# Altium schematic sheet MCU.SchDoc(MCU)
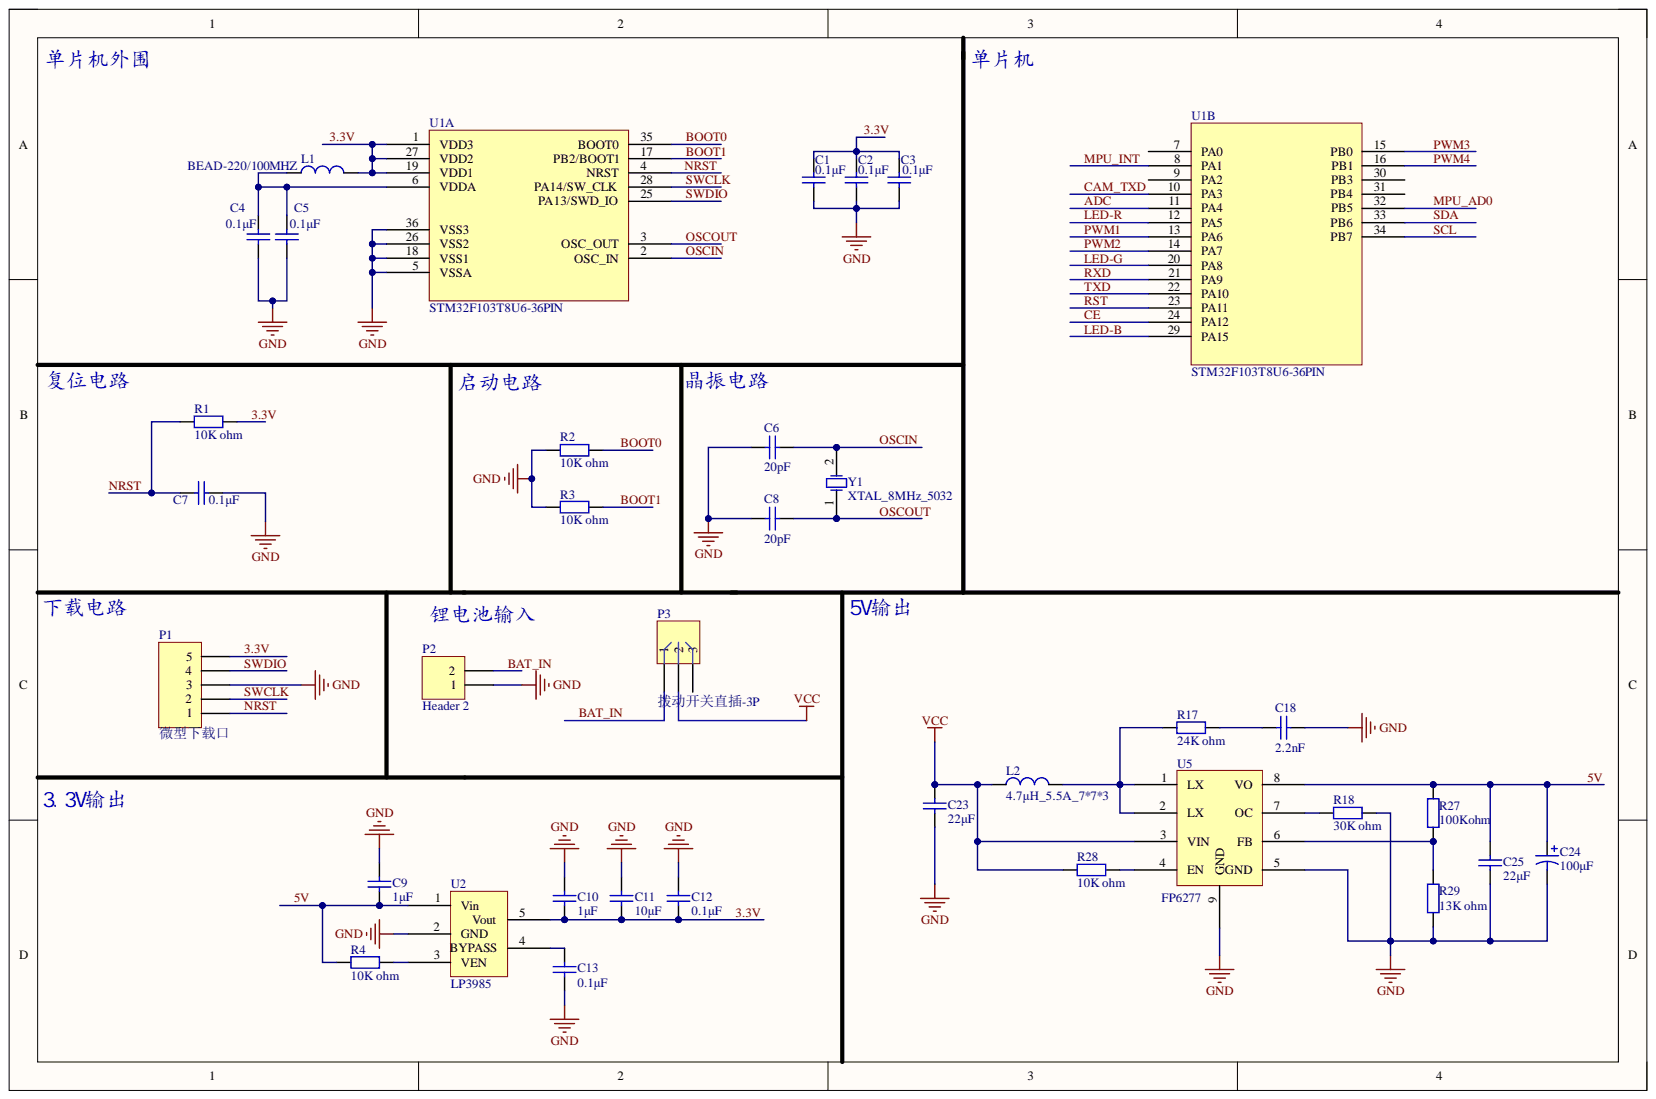
[diagram p0: MCU.SchDoc]

## Parts
C1  0.1μF  [0603C]
C2  0.1μF  [0603C]
C3  0.1μF  [0603C]
C4  0.1μF  [0603C]
C5  0.1μF  [0603C]
C6  20pF  [0603C]
C7  0.1μF  [0603C]
C8  20pF  [0603C]
C9  1μF  [0603C]
C10  1μF  [0603C]
C11  10μF  [0603C]
C12  0.1μF  [0603C]
C13  0.1μF  [0603C]
C18  2.2nF  [0603C]
C23  22μF  [1206C]
C24  100μF  [D7343C]
C25  22μF  [1206C]
L1  BEAD-220/100MHZ  [0603R]
L2  4.7μH_5.5A_7*7*3  [7*7*3mm - 06030]
P1  微型下载口  [微型下载口]
P2  Header 2  [HDR1X2]
P3  拨动开关直插-3P  [拨动开关-3P]
R1  10K ohm  [0603R]
R2  10K ohm  [0603R]
R3  10K ohm  [0603R]
R4  10K ohm  [0603R]
R17  24K ohm  [0603R]
R18  30K ohm  [0603R]
R27  100Kohm  [0603R]
R28  10K ohm  [0603R]
R29  13K ohm  [0603R]
U1  STM32F103T8U6-36PIN  [QFN-36N]  (parts A,B)
U2  LP3985  [SOT-23-5]
U5  FP6277  [SO8 - FP6277]
Y1  XTAL_8MHz_5032  [5032]

## Nets
GND: C1.1 C2.1 C3.1 C4.1 C5.1 C6.1 C7.1 C8.1 C9.1 C10.1 C11.2 C12.2 C13.1 C18.1 C23.1 C24.2 C25.1 P1.3 P2.1 R2.1 R3.1 R18.2 R29.1 U1.5(VSSA) U1.18(VSS1) U1.26(VSS2) U1.36(VSS3) U2.2(GND) U5.5(GND) U5.9(GND)
VCC: C23.2 L2.1 P3.2 R28.1 U5.3(VIN)
3.3V: C1.2 C2.2 C3.2 C10.2 C11.1 C12.1 L1.2 P1.5 R1.2 U1.1(VDD3) U1.19(VDD1) U1.27(VDD2) U2.5(Vout)
5V: C9.2 C24.1 C25.2 R4.1 R27.2 U2.1(Vin) U5.8(VO)
ADC: U1.11(PA4)
BAT_IN: P2.2 P3.1
BOOT0: R2.2 U1.35(BOOT0)
BOOT1: R3.2 U1.17(PB2/BOOT1)
CAM_TXD: U1.10(PA3)
CE: U1.24(PA12)
LED-B: U1.29(PA15)
LED-G: U1.20(PA8)
LED-R: U1.12(PA5)
MPU_AD0: U1.32(PB5)
MPU_INT: U1.8(PA1)
NRST: C7.2 P1.1 R1.1 U1.4(NRST)
OSCIN: C6.2 U1.2(OSC_IN) Y1.2
OSCOUT: C8.2 U1.3(OSC_OUT) Y1.1
PWM1: U1.13(PA6)
PWM2: U1.14(PA7)
PWM3: U1.15(PB0)
PWM4: U1.16(PB1)
RST: U1.23(PA11)
RXD: U1.21(PA9)
SCL: U1.34(PB7)
SDA: U1.33(PB6)
SWCLK: P1.2 U1.28(PA14/SW_CLK)
SWDIO: P1.4 U1.25(PA13/SWD_IO)
TXD: U1.22(PA10)
NetC4_2: C4.2 C5.2 L1.1 U1.6(VDDA)
NetC13_2: C13.2 U2.4(BYPASS)
NetC18_2: C18.2 R17.1
NetL2_2: L2.2 R17.2 U5.1(LX) U5.2(LX)
NetR4_2: R4.2 U2.3(VEN)
NetR18_1: R18.1 U5.7(OC)
NetR27_1: R27.1 R29.2 U5.6(FB)
NetR28_2: R28.2 U5.4(EN)

Not connected: P3 3; U1 7(PA0) 9(PA2) 30(PB3) 31(PB4)

## Library data of the parts
C1-C5 C7 C12 C13 = Cap_0.1μF_50V_0603: 0.1μF_50V,X7R,±5%; 制造商: muRata; 单位: PCS; 物料名称: 贴片陶瓷电容; 物料型号: GRM188R71H104JA93D; 物料编码: TCC0087; Component Kind: Standard; 物料规格: 0.1μF_50V,X7R,±5%; Library Name: Yang_Lib.IntLib
C6 C8 = Cap_20pF_50V_0603: 20pF_50V,CH,±5%; 制造商: muRata; 单位: PCS; 物料名称: 贴片陶瓷电容; 物料型号: GRM1882C1H200JA01D; 物料编码: TCC0036; Component Kind: Standard; 物料规格: 20pF_50V,CH,±5%; Library Name: Yang_Lib.IntLib
C9 C10 = Cap_1μF_50V_0603: 1μF_50V,X5R,±10%; 制造商: muRata; 单位: PCS; 物料名称: 贴片陶瓷电容; 物料型号: GRM188R61H105KAALD; 物料编码: TCC0040; Component Kind: Standard; 物料规格: 1μF_50V,X5R,±10%; Library Name: Yang_Lib.IntLib
C11 = Cap_10μF_35V_0603: 10μF_35V,X5R,20%; 制造商: muRata; 单位: PCS; 物料名称: 贴片陶瓷电容; 物料型号: GRM188R6YA106MA73D; 物料编码: TCC0071; 物料规格: 10μF_35V,20%; Component Kind: Standard; Library Name: Yang_Lib.IntLib
C18 = Cap_2.2nF_50V_0603: 2.2nF_50V,X7R,10%; 制造商: muRata; 单位: PCS; 物料名称: 贴片陶瓷电容; 物料型号: NA; Component Kind: Standard; 物料编码: NA; 物料规格: 2.2nF_50V,X7R,10%; Library Name: Yang_Lib.IntLib
C23 C25 = Cap_22μF_35V_1206: 22μF_35V,20%; 制造商: TDK; 单位: PCS; 物料名称: 贴片陶瓷电容; 物料型号: C3216JB1V226M160AC; 物料编码: NA; Component Kind: Standard; 物料规格: 22μF_35V,20%; Library Name: Yang_Lib.IntLib
C24 = Cap_100μF_16V_D7343: 100μF_16V; 制造商: AVX; 单位: PCS; 物料名称: 钽电容; 物料型号: NA; Component Kind: Standard; 物料编码: NA; 物料规格: 100μF_16V; Library Name: Yang_Lib.IntLib
L1 = BEAD-220/100MHZ_0603: 制造商: TDK; 单位: PCS; 物料名称: 磁珠; 物料型号: MMZ1608B221CTAH0; 物料编码: TCZ0006; Component Kind: Standard; Description: BEAD-220/100MHZ; 物料规格: BEAD-220/100MHZ; Library Name: Yang_Lib.IntLib
L2 = 4.7μH_5.5A_7*7*3: 4.7μH_5.5A_; 制造商: 台湾奇力新; 单位: PCS; 物料名称: 功率电感; 物料型号: MHCC10040-4R7M-R8_06030; 物料编码: NA; Component Kind: Standard; 物料规格: 4.7μH_5.5A_7*7*3; Library Name: Yang_Lib.IntLib
P1 = 微型下载口: 制造商: NA; 单位: PCS; Component Kind: Standard; Description: 微型下载口; 物料名称: 微型下载口; 物料型号: 微型下载口; 物料编码: NA; 物料规格: 微型下载口; Library Name: Yang_Lib.IntLib
P2 = Header 2: Header, 2-Pin; Component Kind: Standard; LatestRevisionDate: 17-Jul-2002; LatestRevisionNote: Re-released for DXP Platform.; Library Name: Miscellaneous Connectors.IntLib; Signal Integrity: Connector; Publisher: Altium Limited
P3 = 拨动开关直插-3P: 制造商: NA; 单位: PCS; Component Kind: Standard; Description: 拨动开关直插-3P; 物料名称: 拨动开关直插-3P; 物料型号: 拨动开关直插-3P; 物料编码: NA; 物料规格: 拨动开关直插-3P; Library Name: Yang_Lib.IntLib
R1-R4 R28 = Res_10K ohm_0603: 10K ohm,1%; 制造商: YAGEO; 单位: PCS; 物料名称: 贴片电阻; 物料型号: RC0603FR-0710KL; 物料编码: TRS0020; Component Kind: Standard; 物料规格: 10K ohm,1%; Library Name: Yang_Lib.IntLib
R17 = Res_24K ohm_0603: 24K ohm,1%; 制造商: YAGEO; 单位: PCS; 物料名称: 贴片电阻; 物料型号: RC0603FR-0724KL; 物料编码: NA; Component Kind: Standard; 物料规格: 24K ohm,1%; Library Name: Yang_Lib.IntLib
R18 = Res_30K ohm_0603: 30K ohm,1%; 制造商: YAGEO; 单位: PCS; 物料名称: 贴片电阻; 物料型号: RC0603FR-0730KL; 物料编码: TRS0028; Component Kind: Standard; 物料规格: 30K ohm,1%; Library Name: Yang_Lib.IntLib
R27 = Res_100Kohm_0603: 100K ohm_5%; 制造商: YAGEO; 单位: PCS; 物料名称: 贴片电阻; 物料型号: RC0603JR-07100K; 物料编码: TRS0032; Component Kind: Standard; 物料规格: 100K ohm_5%; Library Name: Yang_Lib.IntLib
R29 = Res_13K ohm_0603: 13K ohm,1%; 制造商: YAGEO; 单位: PCS; 物料名称: 贴片电阻; Component Kind: Standard; 物料规格: 13K ohm,1%; Library Name: Yang_Lib.IntLib
U1 = STM32F103T8U6-36PIN: 制造商: ST; 单位: PCS; 物料名称: 单片机; 物料编码: NA; Component Kind: Standard; 物料型号: STM32F103T8U6-36PIN; 物料规格: STM32F103T8U6-36PIN; Library Name: Yang_Lib.IntLib
U2 = LP3985: 制造商: National Semiconductor; 单位: PCS; 物料名称: 3.3V LDO; 物料型号: LP3985IM5-3.3/NOPB; 物料编码: TIC0029; Component Kind: Standard; Description: LP3985; 物料规格: LP3985; Library Name: Yang_Lib.IntLib
U5 = FP6277: 制造商: 台湾远翔; 单位: PCS; 物料名称: 升压芯片; 物料编码: NA; Component Kind: Standard; Description: FP6277; 物料型号: FP6277; 物料规格: FP6277; Library Name: Yang_Lib.IntLib
Y1 = XTAL_8MHz_5032: XTAL_8MHz; 制造商: TAITIEN; 单位: PCS; 物料名称: 晶振; 物料型号: XIHCCCNANF-8.000; 物料编码: NA; 物料规格: 8MHz; Component Kind: Standard; Library Name: Yang_Lib.IntLib
